# Altium schematic sheet Sensors.SchDoc(Sensors)
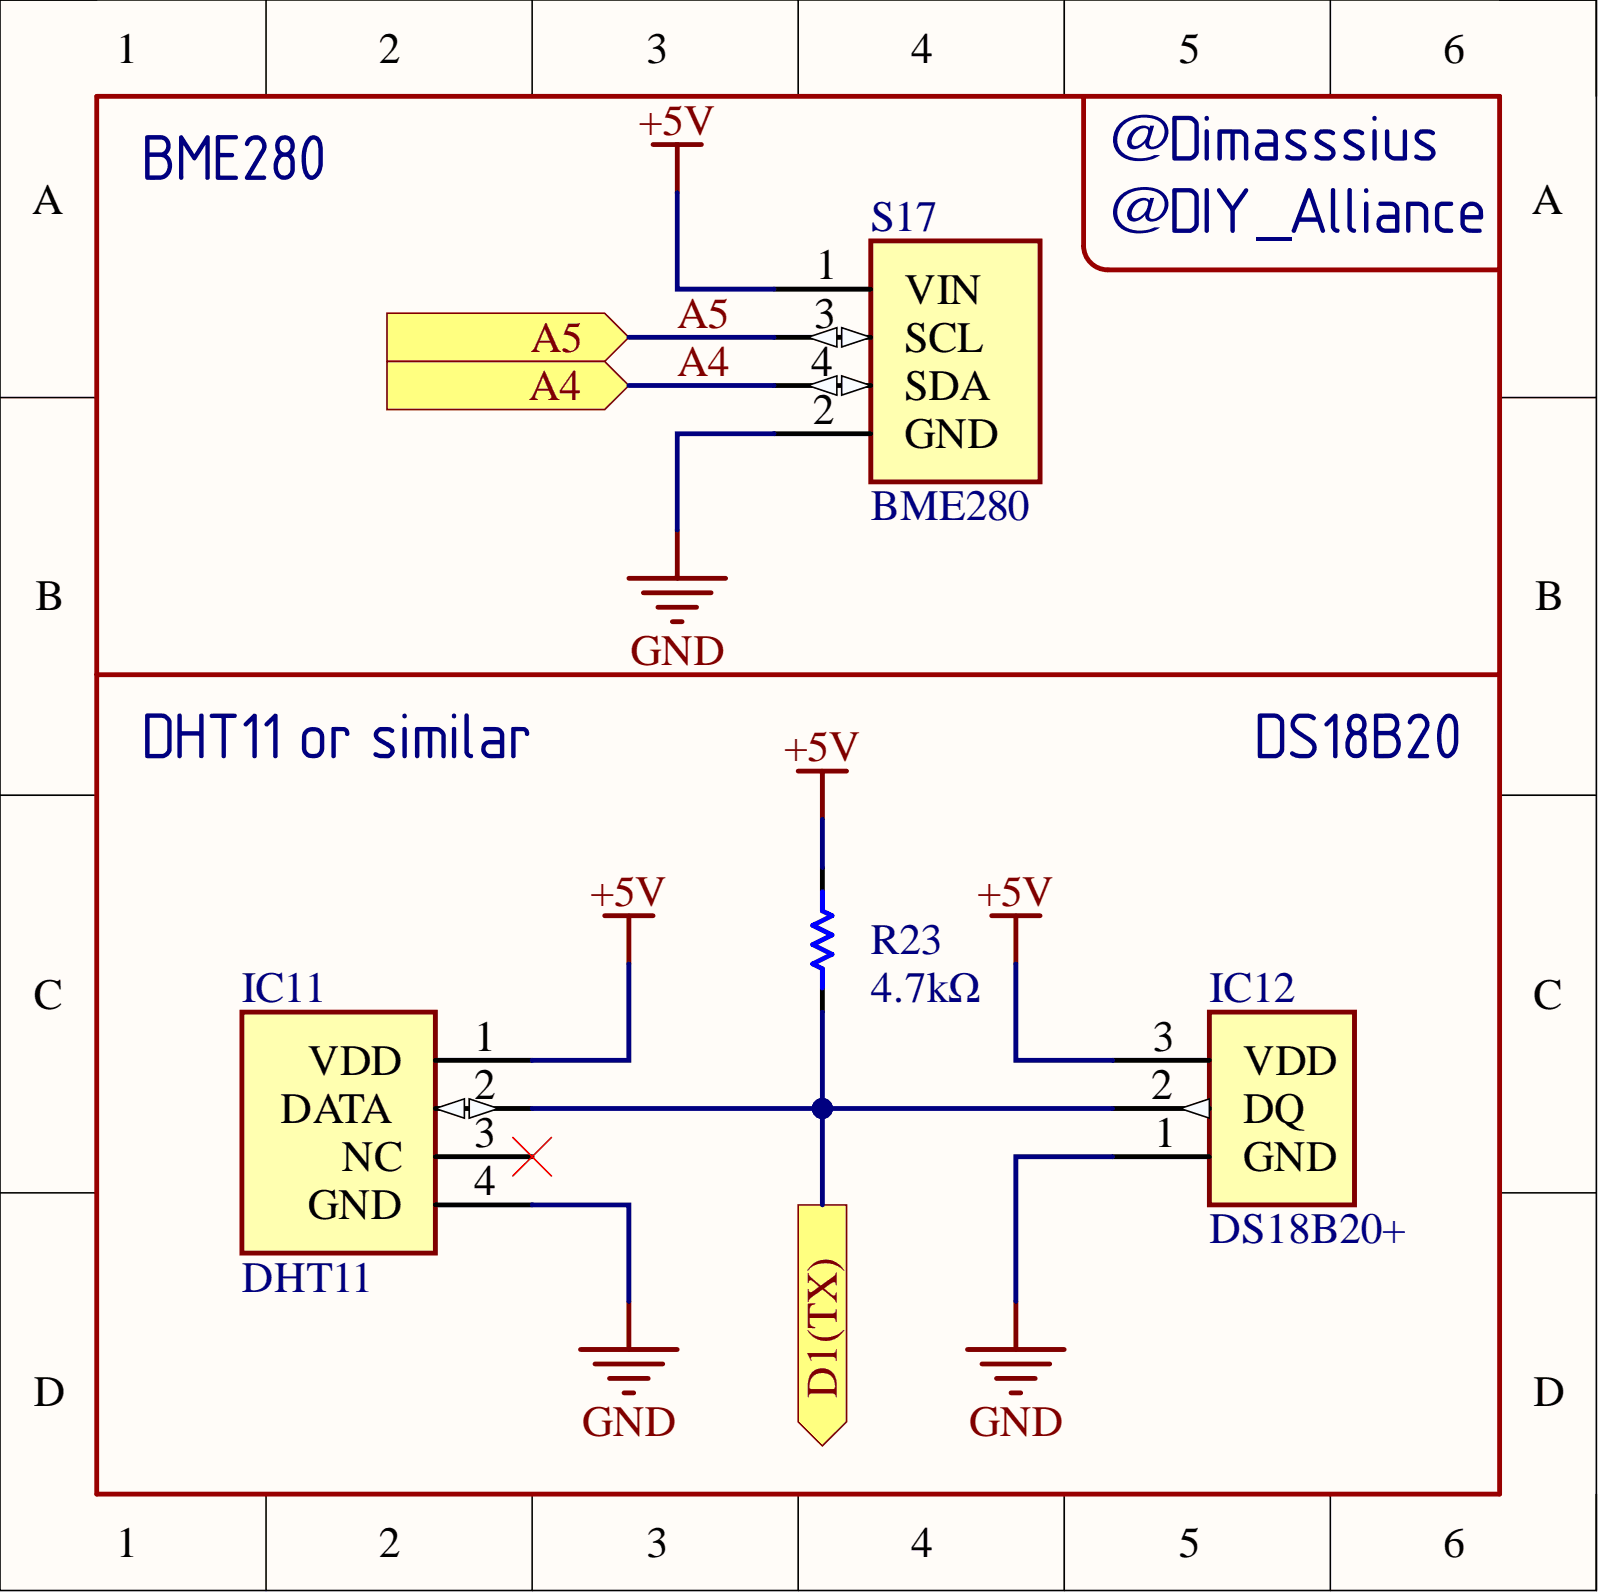
[diagram p0: Sensors.SchDoc]

## Parts
IC11  DHT11  [DHT11 - SMD]
IC12  DS18B20+  [TO-92]
R23  4.7kΩ  [RES_THD/SMD_R0603_R0402_4.7K_THD]
R23
R23
S17  BME280  [BME280_DEVBOARD]

## Ports
A4, A5, D1(TX)

## Nets
+5V: IC11.1(VDD) IC12.3 R23.2 S17.1(VIN)
GND: IC11.4(GND) IC12.1 S17.2(GND)
A4: S17.4(SDA) ; port A4
A5: S17.3(SCL) ; port A5
D1(TX): IC11.2(DATA) IC12.2 R23.1 ; port D1(TX)

Not connected: IC11 3(NC)

## Library data of the parts
IC11 = DHT11: Temperature and Humidity Sensor, SIP-4, ROHS; Component Kind: Standard; Library Name: SamacSys.SchLib; Value: DHT11
IC12 = DS18B20+: Temperature Sensor, THD, TO-92, 3V~5.5V, -55℃~+125℃, ±0.5, Single Bus, RoHS; Component Kind: Standard; Datasheet Link: https://ms.componentsearchengine.com/Datasheets/2/DS18B20+.pdf; Height: 4.95mm; Library Name: SamacSys.SchLib; Value: DS18B20+
R23 = RES_THD/SMD_4.7KΩ_R0603_R0402_THD: Resistor, Metal Film Resistor, Through Hole, D2.3xL6.5mm, 4.7kΩ, ±1%, 250mW, Through Hole Resistors, ROHS; Component Kind: Standard; Library Name: Resistors.SchLib; Value: 4.7kΩ
S17 = BME280_DEVBOARD: Development Board, LGA-8(2.5x2.5), Temperature and Humidity Sensor, ROHS; Component Kind: Standard; Library Name: SamacSys.SchLib; Value: BME280
